# Altium schematic sheet LAN.SchDoc(LAN)
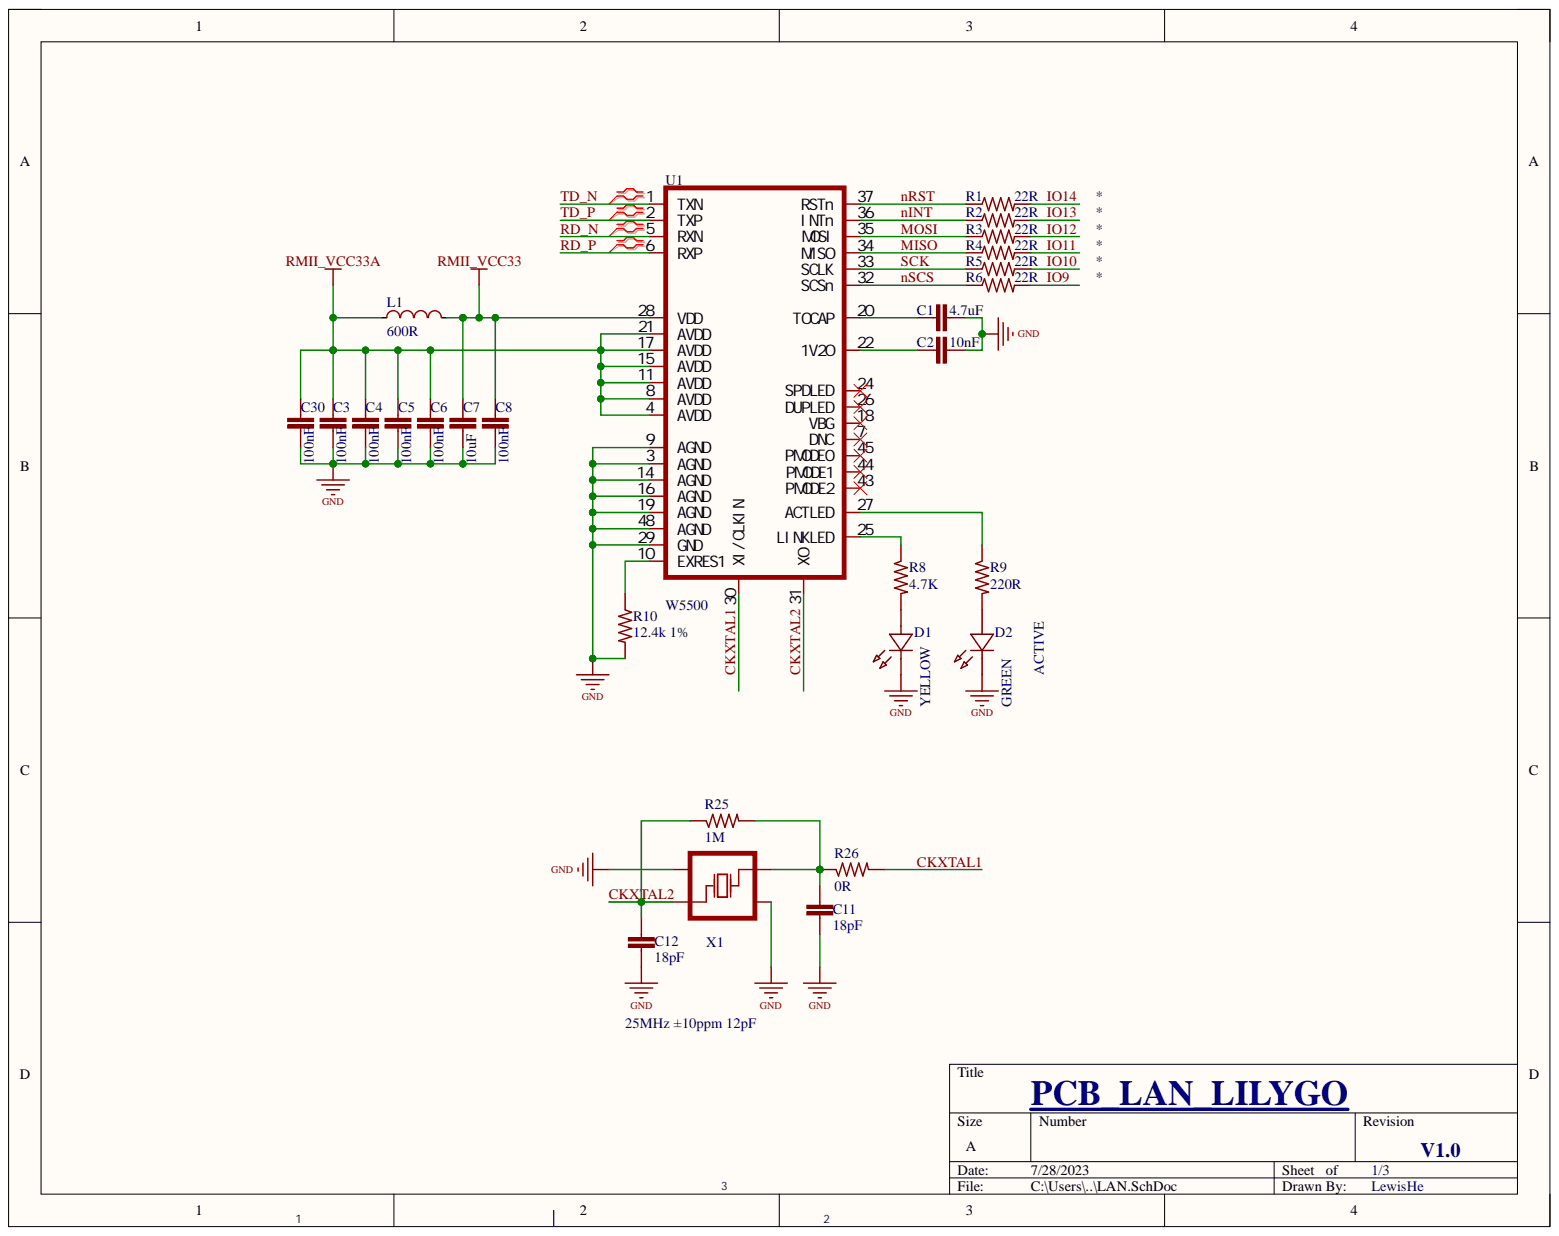
[diagram p0: LAN.SchDoc]

## Parts
C1  4.7uF  [C0402]
C2  10nF  [C0402]
C3  100nF  [C0402]
C4  100nF  [C0402]
C5  100nF  [C0402]
C6  100nF  [C0402]
C7  10uF  [C0402]
C8  100nF  [C0402]
C11  18pF  [C0402]
C12  18pF  [C0402]
C30  100nF  [C0402]
D1  YELLOW  [LED0603_RED]
D2  GREEN  [LED0603_RED]
L1  600R  [L0402]
R1  22R  [R0402]
R2  22R  [R0402]
R3  22R  [R0402]
R4  22R  [R0402]
R5  22R  [R0402]
R6  22R  [R0402]
R8  4.7K  [R0402]
R9  220R  [R0402]
R10  12.4k 1%  [R0402]
R25  1M  [R0402]
R26  0R  [R0402]
U1  W5500  [LQFP-48_L7.0-W7.0-P0.50-LS9.0-BL]
X1  25MHz ±10ppm 12pF  [CRYSTAL-SMD_4P-L3.2-W2.5-BL]

## Nets
GND: C1.1 C2.1 C3.2 C4.2 C5.2 C6.2 C7.1 C8.1 C11.2 C12.1 C30.2 D1.2 D2.2 R10.2 U1.3(AGND) U1.9(AGND) U1.12 U1.13 U1.14(AGND) U1.16(AGND) U1.19(AGND) U1.23 U1.29(GND) U1.38 U1.39 U1.40 U1.41 U1.42 U1.46 U1.47 U1.48(AGND) X1.2 X1.4
RMII_VCC33: C7.2 C8.2 L1.2 U1.28(VDD)
RMII_VCC33A: C3.1 C4.1 C5.1 C6.1 C30.1 L1.1 U1.4(AVDD) U1.8(AVDD) U1.11(AVDD) U1.15(AVDD) U1.17(AVDD) U1.21(AVDD)
CKXTAL1: R26.2 U1.30(XI/CLKIN)
CKXTAL2: C12.2 R25.1 U1.31(XO) X1.1
IO9: R6.2
IO10: R5.2
IO11: R4.2
IO12: R3.2
IO13: R2.2
IO14: R1.2
MISO: R4.1 U1.34(MISO)
MOSI: R3.1 U1.35(MOSI)
nINT: R2.1 U1.36(INTn)
nRST: R1.1 U1.37(RSTn)
nSCS: R6.1 U1.32(SCSn)
RD_N: U1.5(RXN)
RD_P: U1.6(RXP)
SCK: R5.1 U1.33(SCLK)
TD_N: U1.1(TXN)
TD_P: U1.2(TXP)
NetC1_2: C1.2 U1.20(TOCAP)
NetC2_2: C2.2 U1.22(1V2O)
NetC11_1: C11.1 R25.2 R26.1 X1.3
NetD1_1: D1.1 R8.2
NetD2_1: D2.1 R9.2
NetR8_1: R8.1 U1.25(LINKLED)
NetR9_1: R9.1 U1.27(ACTLED)
NetR10_1: R10.1 U1.10(EXRES1)

Not connected: U1 7(DNC) 18(VBG) 24(SPDLED) 26(DUPLED) 43(PMODE2) 44(PMODE1) 45(PMODE0)

## Library data of the parts
C1-C8 C11 C12 C30 = C0201: 0201  贴片电容; Component Kind: Standard; Library Name: 常用.SCHLIB
D1 D2 = LED0603: Component Kind: Standard; Description: LED0603; Library Name: 常用.SCHLIB
L1 = L0402: Component Kind: Standard; Description: L0402; Library Name: 常用.SCHLIB
R1-R6 R8-R10 R25 R26 = R0201: Component Kind: Standard; Library Name: 常用.SCHLIB
X1 = X1E000021013900: 晶振类型:贴片无源晶振;频率:25MHz;频率公差:±10ppm;负载电容:12pF;等效串联电阻(ESR):40Ω;工作温度:-40℃~+85℃;; Component Kind: Standard; Library Name: 常用.SCHLIB
U1 = W5500: Component Kind: Standard; Library Name: 常用.SCHLIB
